# Altium schematic sheet Headers.SchDoc(Headers)
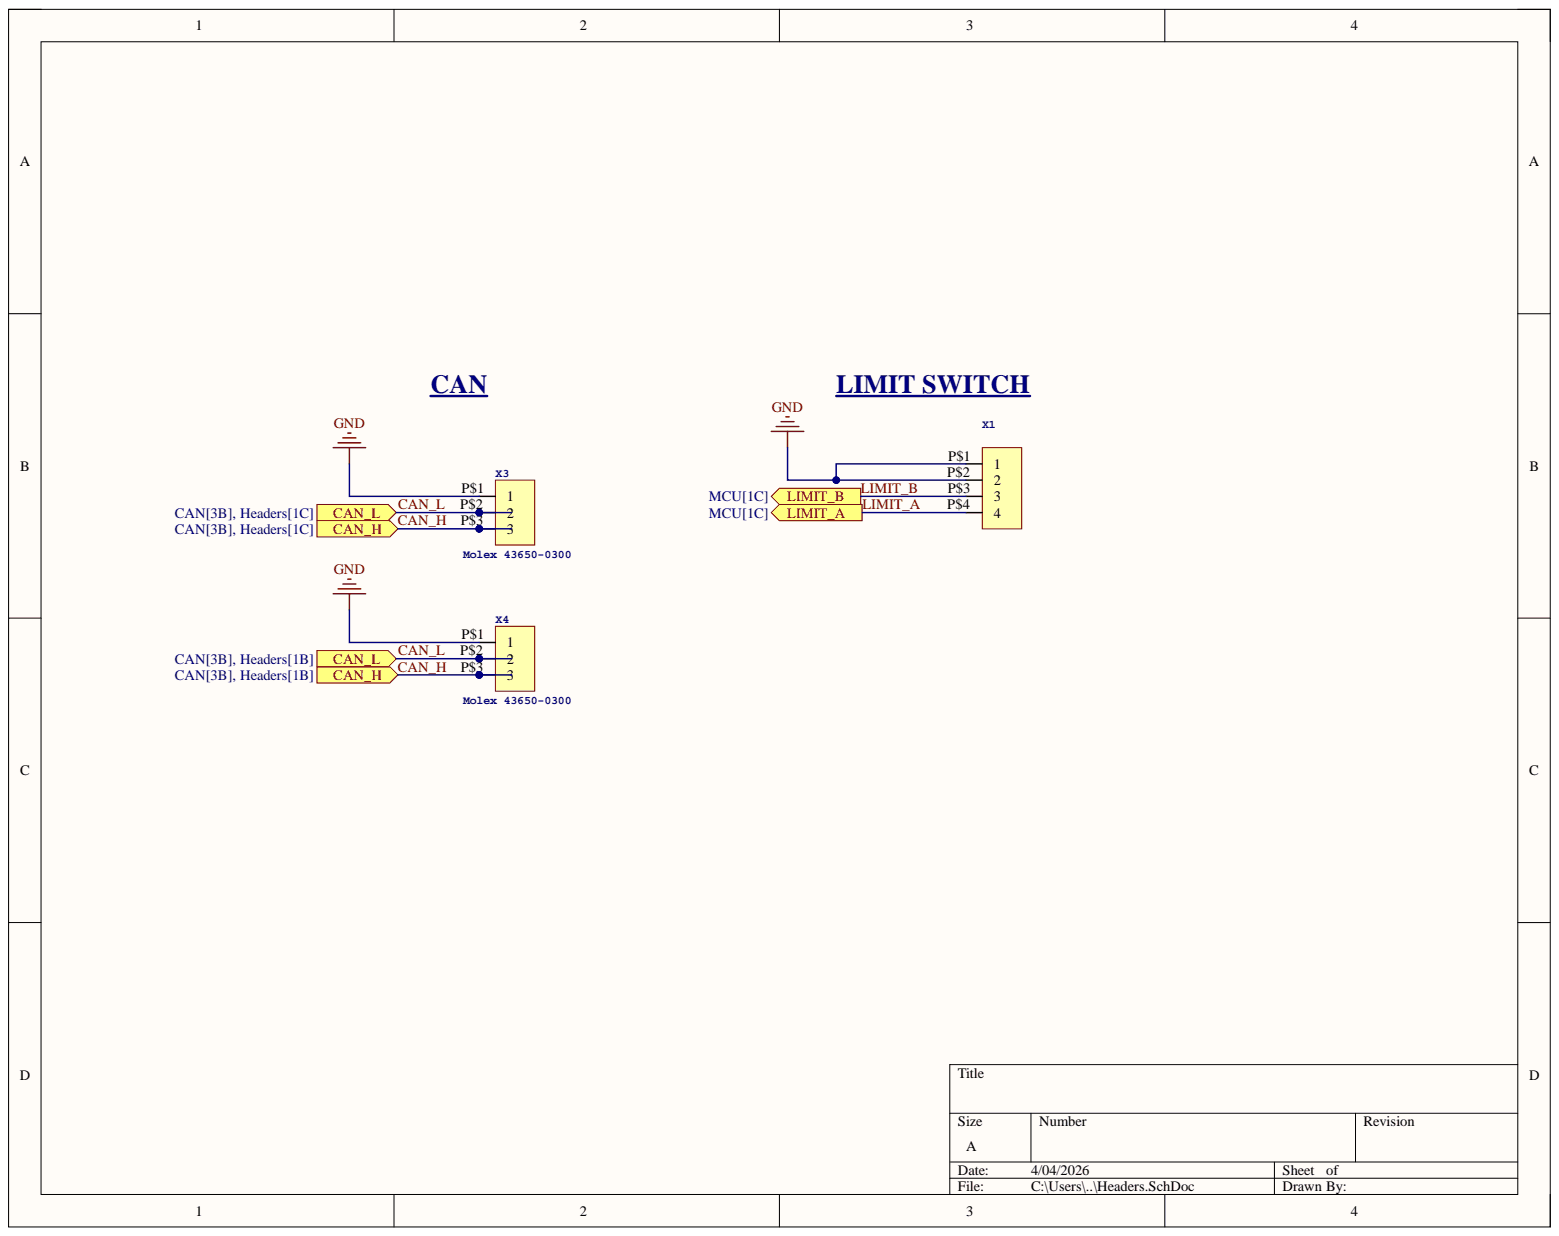
[diagram p0: Headers.SchDoc]

## Parts
X1  Molex - Micro-Fit 3.0 Series connector,  3.00mm (0.118") pitch header, 4 positions.  [43045-0400]  (value not drawn)
X3  Molex 43650-0300  [43650-0300]
X4  Molex 43650-0300  [43650-0300]

## Ports
CAN_H, CAN_L, LIMIT_A, LIMIT_B

## Nets
GND: X1.P$1 X1.P$2 X3.P$1 X4.P$1
CAN_H: X3.P$3 X4.P$3 ; port CAN_H
CAN_L: X3.P$2 X4.P$2 ; port CAN_L
LIMIT_A: X1.P$4 ; port LIMIT_A
LIMIT_B: X1.P$3 ; port LIMIT_B

## Library data of the parts
X1 = HEADER_POS4_?43045-0401: GateName_1: G$1; Component Kind: Standard; DeviceName: 43045-0401; DeviceSetName: HEADER_POS4_?; Library Name: Molex Microfit.IntLib; LibraryName: con-molex-micro-fit-3_0_2; Package: 43045-0400; SymbolName_1: HEADER_POS4
X3 X4 = Micro-Fit Molex 3 Pin Right Angle: DeviceSetName: HEADER_POS3_?; GateName_1: G$1; SymbolName_1: HEADER_POS3; Component Kind: Standard; Description: Molex 43650-0300; DeviceName: 43650-0300; Library Name: Molex Microfit.IntLib; LibraryName: con-molex-micro-fit-3_0_2; Package: 43650-0300
